# Altium schematic sheet 3模块2.SchDoc(3模块2)
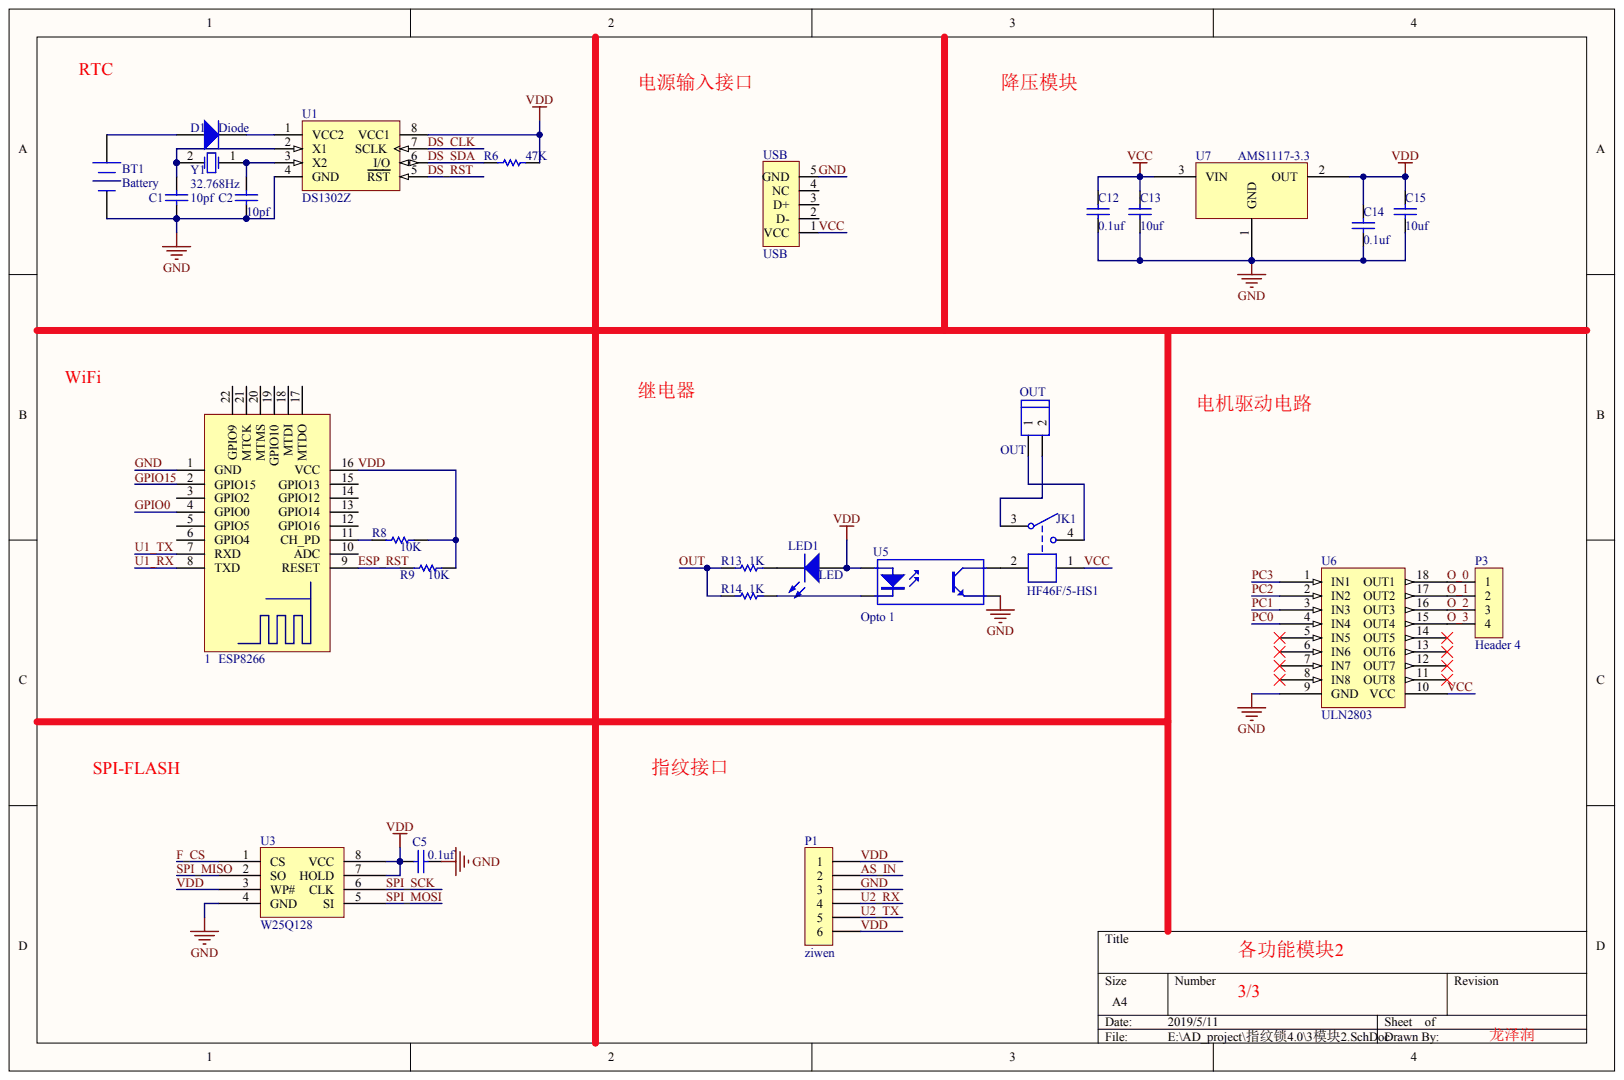
[diagram p0: 3模块2.SchDoc]

## Parts
1  ESP8266
BT1  Battery
C1  值: 10pf  (hidden comment: Cap 1)
C2  值: 10pf  (hidden comment: Cap 1)
C5  值: 0.1uf  (hidden comment: Cap 1)
C12  值: 0.1uf  (hidden comment: Cap 1)
C13  值: 10uf  (hidden comment: Cap 1)
C14  值: 0.1uf  (hidden comment: Cap 1)
C15  值: 10uf  (hidden comment: Cap 1)
D1  Diode
JK1  HF46F/5-HS1
LED1  LED
OUT  OUT
P1  ziwen
P3  Header 4
R6  值: 47K  (hidden comment: Res 1)
R8  值: 10K  (hidden comment: Res 1)
R9  值: 10K  (hidden comment: Res 1)
R13  值: 1K  (hidden comment: Res 1)
R14  值: 1K  (hidden comment: Res 1)
U1  DS1302Z
U3  W25Q128
U5  Opto 1
U6  ULN2803
U7  AMS1117-3.3
USB  USB
Y1  值: 32.768Hz  (hidden comment: XTAL)

## Nets
AS_IN: P1.2
DS_CLK: U1.7(SCLK)
DS_RST: U1.5(RST)
DS_SDA: R6.2 U1.6(I/O)
ESP_RST: 1.9(RESET) R9.2
F_CS: U3.1(CS)
GND: 1.1(GND) BT1.2 C1.2 C2.2 C5.1 C12.2 C13.2 C14.2 C15.2 P1.3 U1.4(GND) U3.4(GND) U5.3 U6.9(GND) U7.1 USB.5
GPIO0: 1.4(GPIO0)
GPIO15: 1.2(GPIO15)
O_0: P3.1 U6.18(OUT1)
O_1: P3.2 U6.17(OUT2)
O_2: P3.3 U6.16(OUT3)
O_3: P3.4 U6.15(OUT4)
OUT: R13.2 R14.2
PC0: U6.4(IN4)
PC1: U6.3(IN3)
PC2: U6.2(IN2)
PC3: U6.1(IN1)
SPI_MISO: U3.2(SO)
SPI_MOSI: U3.5(SI)
SPI_SCK: U3.6(CLK)
U1_RX: 1.8(TXD)
U1_TX: 1.7(RXD)
U2_RX: P1.4
U2_TX: P1.5
VCC: C12.1 C13.1 JK1.1 U6.10(VCC) U7.3 USB.1(VCC)
VDD: 1.16(VCC) C5.2 C14.1 C15.1 LED1.1 P1.1 P1.6 R6.1 R8.1 R9.1 U1.8(VCC1) U3.3(WP#) U3.7(HOLD) U3.8(VCC) U5.1 U7.2
Net1_11: 1.11(CH_PD) R8.2
NetBT1_1: BT1.1 D1.1
NetC1_1: C1.1 U1.2(X1) Y1.2
NetC2_1: C2.1 U1.3(X2) Y1.1
NetD1_2: D1.2 U1.1(VCC2)
NetJK1_2: JK1.2 U5.4
NetJK1_3: JK1.3 OUT.2
NetJK1_4: JK1.4 OUT.1
NetLED1_2: LED1.2 R13.1
NetR14_1: R14.1 U5.2

Not connected: 1 3(GPIO2) 5(GPIO5) 6(GPIO4) 10(ADC) 12(GPIO16) 13(GPIO14) 14(GPIO12) 15(GPIO13) 17(MTDO) 18(MTDI) 19(GPIO10) 20(MTMS) 21(MTCK) 22(GPIO9); U6 5(IN5) 6(IN6) 7(IN7) 8(IN8) 11(OUT8) 12(OUT7) 13(OUT6) 14(OUT5); USB 2(D-) 3(D+) 4(NC)

## Library data of the parts
JK1 = (no symbol name): BOM名称: 5V继电器; 版权归属: 源创客; 学习资源: www.ooocke.com
LED1 = (no symbol name): 值: GRN
U7 = (no symbol name): 封装: SOT-223
1 BT1 C1 C2 C5 C12-C15 D1 OUT P1 P3 R6 R8 R9 R13 R14 U1 U3 U5 U6 USB Y1 = (no symbol name)
  P1 P3: LatestRevisionDate: 17-Jul-2002; LatestRevisionNote: Re-released for DXP Platform.; Publisher: Altium Limited
  U1: : DS1302
